# Altium schematic sheet HT12D.SchDoc(HT12D)
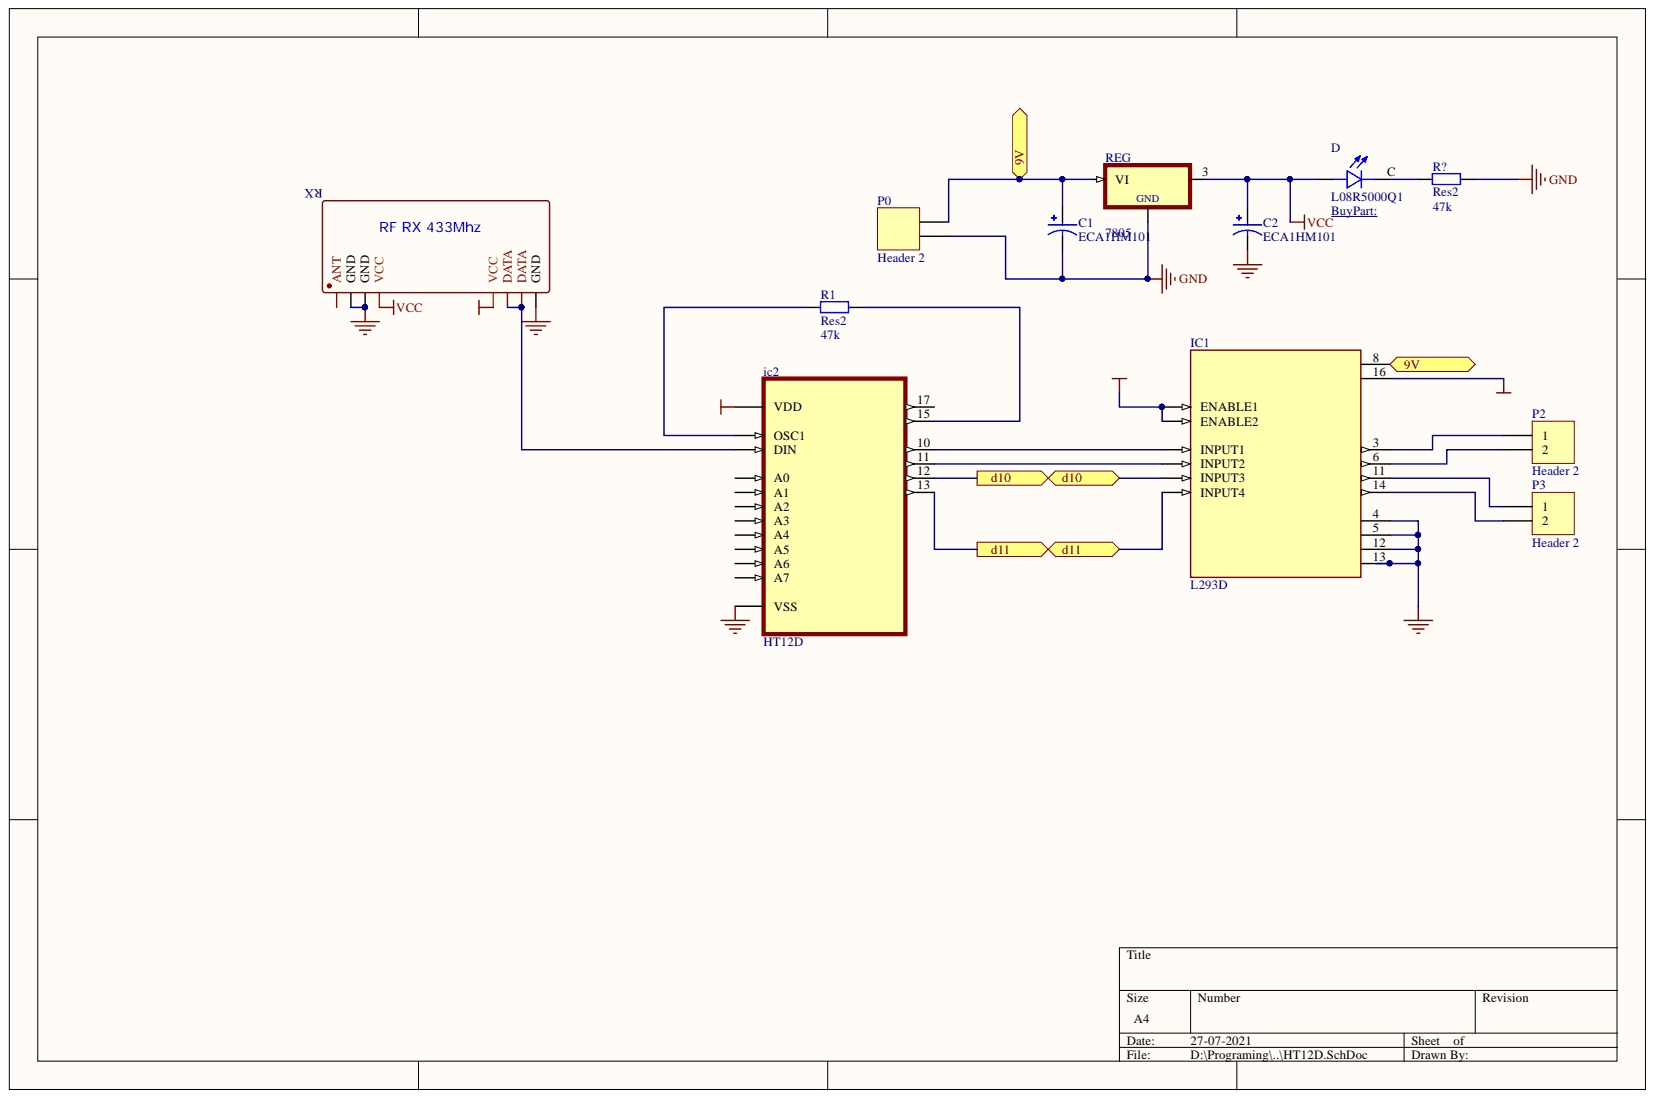
[diagram p0: HT12D.SchDoc]

## Parts
C1  ECA1HM101  [CAPPRD350W60D800H1150]
C2  ECA1HM101  [CAPPRD350W60D800H1150]
D  L08R5000Q1  [LEDRD254W57D500H1070]
IC1  L293D  [DIP880W50P254L2000H510Q16]
ic2  HT12D  [DIP254P762X342-18]
P0  Header 2  [HDR1X2]
P2  Header 2  [HDR1X2]
P3  Header 2  [HDR1X2]
R1  Res2  value 47k  [AXIAL-0.4]
R?  Res2  value 47k  [AXIAL-0.4]
REG  7805  [TO92-]
RX  (no value)  [PCBComponent_1]

## Ports
9V, d10, d11

## Nets
GND: C1.N C2.N IC1.4 IC1.5 IC1.12 IC1.13 ic2.9(VSS) P0.1 R?.2 REG.2 RX.2(GND) RX.3(GND) RX.8(GND)
VCC: C2.P D.A IC1.1(ENABLE1) IC1.9(ENABLE2) IC1.16 ic2.18(VDD) REG.3 RX.4(VCC) RX.5(VCC)
9V: C1.P IC1.8 P0.2 REG.1 ; port 9V
d10: IC1.10(INPUT3) ic2.12 ; port d10
d11: IC1.15(INPUT4) ic2.13 ; port d11
NetD_C: D.C R?.1
NetIC1_2: IC1.2(INPUT1) ic2.10
NetIC1_3: IC1.3 P2.1
NetIC1_6: IC1.6 P2.2
NetIC1_7: IC1.7(INPUT2) ic2.11
NetIC1_11: IC1.11 P3.1
NetIC1_14: IC1.14 P3.2
Netic2_14: ic2.14(DIN) RX.6(DATA) RX.7(DATA)
Netic2_15: ic2.15 R1.2
Netic2_16: ic2.16(OSC1) R1.1

Not connected: ic2 1(A0) 2(A1) 3(A2) 4(A3) 5(A4) 6(A5) 7(A6) 8(A7) 17; RX 1(ANT)

## Library data of the parts
C1 C2 = ECA1HM101: 100ÂµF 50V Aluminum Electrolytic Capacitors Radial, Can 2000 Hrs @ 85Â°C; MF: Panasonic; SnapEDA_Link: https://www.snapeda.com/parts/ECA1HM101/Panasonic/view-part/4478734/?ref=snap; Price: None; Package: RADIAL-2 Panasonic; MP: ECA1HM101; Library Name: capacitor electro.IntLib; Component Kind: Standard; Check_prices: https://www.snapeda.com/parts/ECA1HM101/Panasonic/view-part/4478734/?ref=eda; Availability: No availability data
D = L08R5000Q1: LED, 5MM, ORANGE; LED / Lamp Size: 5mm / T-1 3/4; LED Colour: Orange; Typ Luminous Intensity: 4.3mcd; Viewing Angle: ...; MF: LED Technology; SnapEDA_Link: https://www.snapeda.com/parts/L08R5000Q1/LED%20Technology/view-part/423559/?ref=snap; Price: None; Package: None; MP: L08R5000Q1; Library Name: LED, 5MM.IntLib; Component Kind: Standard; Availability: Unavailable
IC1 = L293D: Bipolar Motor Driver Parallel 16-PowerDIP; MF: STMicroelectronics; SnapEDA_Link: https://www.snapeda.com/parts/L293D/STMicroelectronics/view-part/83726/?ref=snap; Price: None; Package: SOIC-20 STMicroelectronics; MP: L293D; Library Name: L293D.IntLib; Component Kind: Standard; Availability: Unavailable
ic2 = HT12D: IC: remote control decoder; DIP18; 2.4Ã·12VDC; MF: Holtek; SnapEDA_Link: https://www.snapeda.com/parts/HT12D/Holtek/view-part/53386/?ref=snap; Price: None; Package: DIP-18 Holtek; MP: HT12D; Library Name: HT12D.IntLib; Component Kind: Standard; Availability: Unavailable
P0 P2 P3 = Header 2: Header, 2-Pin; Signal Integrity: Connector; Library Name: Miscellaneous Connectors.IntLib; LatestRevisionNote: Re-released for DXP Platform.; LatestRevisionDate: 17-Jul-2002; Component Kind: Standard; Publisher: Altium Limited
R1 R? = Res2: Resistor; Simulation: RESISTOR; Signal Integrity: Res; Published: 8-Jun-2000; PackageReference: AXIAL-0.4; PackageDescription: Axial Device, Thru-Hole; 2 Leads; 0.4 in Pin Spacing; Library Name: Miscellaneous Devices.IntLib; LatestRevisionNote: Re-released for DXP Platform.; LatestRevisionDate: 17-Jul-2002; Component Kind: Standard; Publisher: Altium Limited
REG = 7805: 0.250 _6.35mm_ Quick Connect Male Solder Connector Non-Mating End Insulated; MF: Keystone Electronics; SnapEDA_Link: https://www.snapeda.com/parts/7805/Keystone%20Electronics/view-part/615770/?ref=snap; Price: None; Package: None; MP: 7805; Library Name: 7805.IntLib; Component Kind: Standard; Availability: Unavailable
RX = Component_1: Library Name: rx.SchLib; Component Kind: Standard
